# Altium schematic sheet right_led_gaze.SchDoc
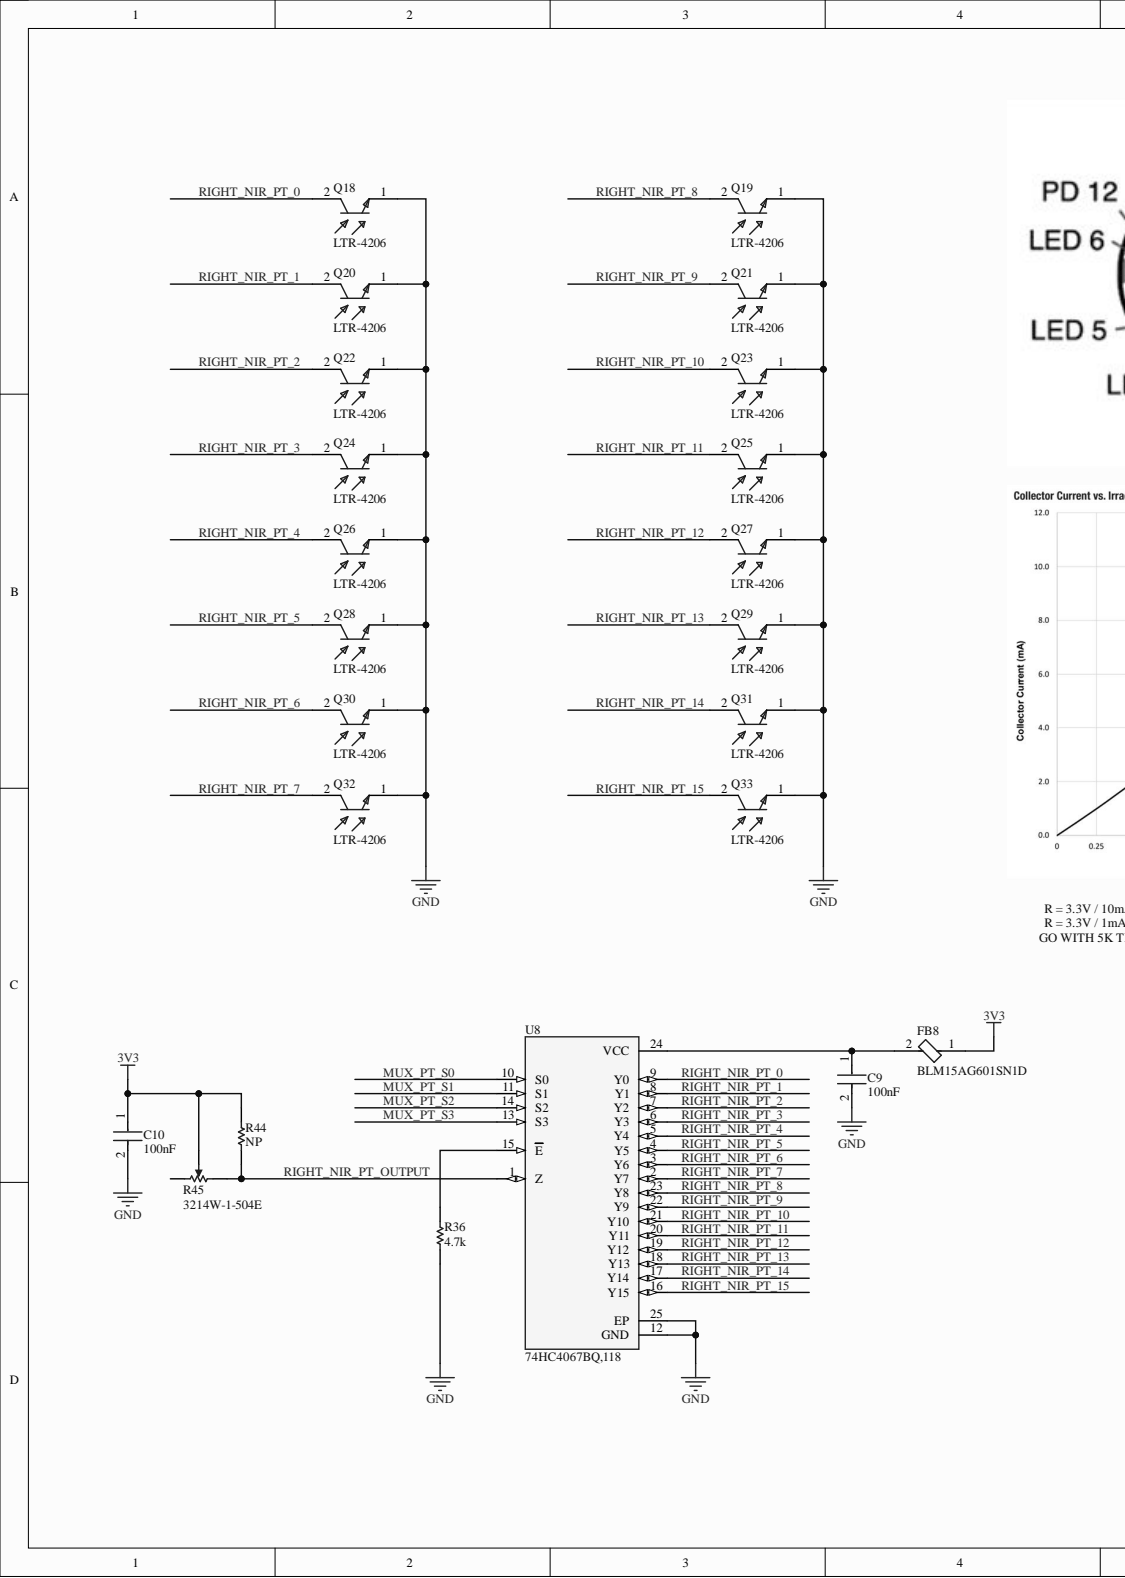
[diagram p0: right_led_gaze.SchDoc, left]
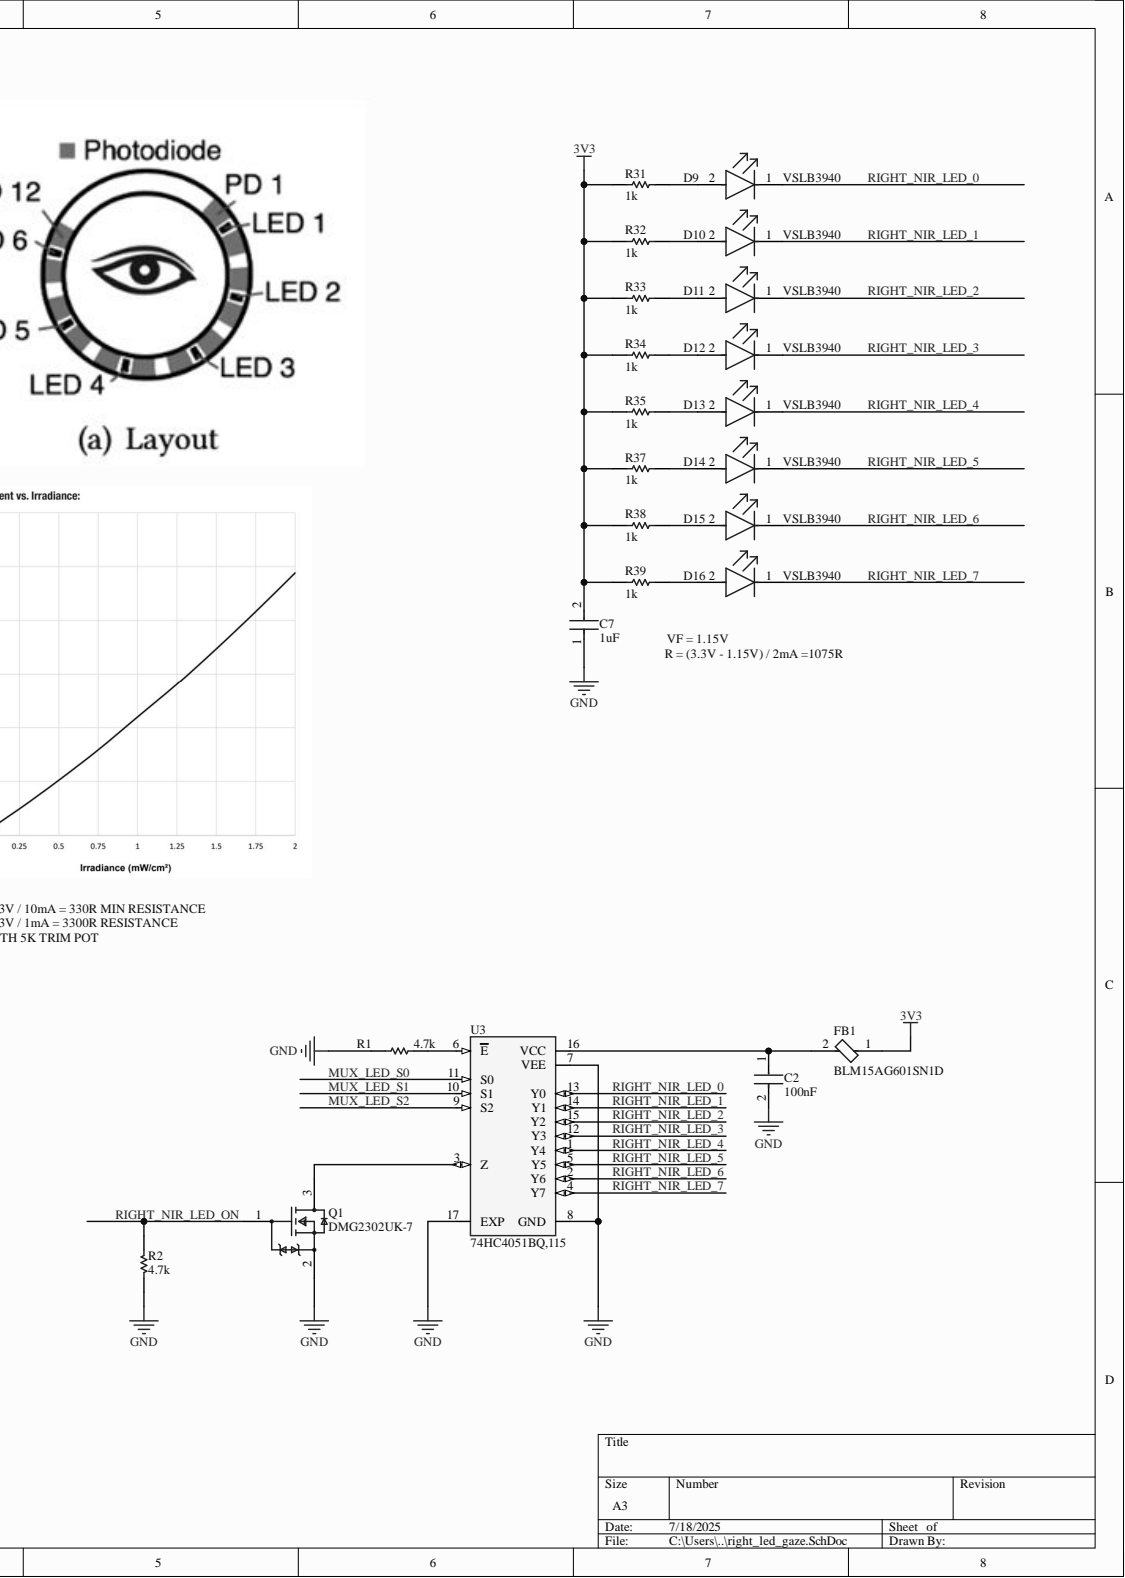
[diagram p1: right_led_gaze.SchDoc, right]

## Parts
C2  Capacitance: 100nF  [FP-GRM15-MFG]  (hidden comment: GRM155R71C104KA88D)
C7  value 1uF  [FP-CC0402-MFG]  (hidden comment: CC0402KRX5R5BB105)
C9  Capacitance: 100nF  [FP-GRM15-MFG]  (hidden comment: GRM155R71C104KA88D)
C10  Capacitance: 100nF  [FP-GRM15-MFG]  (hidden comment: GRM155R71C104KA88D)
D9  VSLB3940  [FP-VSLB3940-MFG]
D10  VSLB3940  [FP-VSLB3940-MFG]
D11  VSLB3940  [FP-VSLB3940-MFG]
D12  VSLB3940  [FP-VSLB3940-MFG]
D13  VSLB3940  [FP-VSLB3940-MFG]
D14  VSLB3940  [FP-VSLB3940-MFG]
D15  VSLB3940  [FP-VSLB3940-MFG]
D16  VSLB3940  [FP-VSLB3940-MFG]
FB1  BLM15AG601SN1D  [FP-BLM15-0_05-IPC_B]
FB8  BLM15AG601SN1D  [FP-BLM15-0_05-IPC_B]
Q1  DMG2302UK-7  [FP-SOT23-MFG]
Q18  LTR-4206  [FP-LTR-4206-MFG]
Q19  LTR-4206  [FP-LTR-4206-MFG]
Q20  LTR-4206  [FP-LTR-4206-MFG]
Q21  LTR-4206  [FP-LTR-4206-MFG]
Q22  LTR-4206  [FP-LTR-4206-MFG]
Q23  LTR-4206  [FP-LTR-4206-MFG]
Q24  LTR-4206  [FP-LTR-4206-MFG]
Q25  LTR-4206  [FP-LTR-4206-MFG]
Q26  LTR-4206  [FP-LTR-4206-MFG]
Q27  LTR-4206  [FP-LTR-4206-MFG]
Q28  LTR-4206  [FP-LTR-4206-MFG]
Q29  LTR-4206  [FP-LTR-4206-MFG]
Q30  LTR-4206  [FP-LTR-4206-MFG]
Q31  LTR-4206  [FP-LTR-4206-MFG]
Q32  LTR-4206  [FP-LTR-4206-MFG]
Q33  LTR-4206  [FP-LTR-4206-MFG]
R1  value 4.7k  [RESC1005X40X25LL05T10]  (hidden comment: CRCW04024K70FKEDHP)
R2  value 4.7k  [RESC1005X40X25LL05T10]  (hidden comment: CRCW04024K70FKEDHP)
R31  Resistance: 1k  [RESC1005X40X25NL05T05]  (hidden comment: ERJ-2RKF1001X)
R32  Resistance: 1k  [RESC1005X40X25NL05T05]  (hidden comment: ERJ-2RKF1001X)
R33  Resistance: 1k  [RESC1005X40X25NL05T05]  (hidden comment: ERJ-2RKF1001X)
R34  Resistance: 1k  [RESC1005X40X25NL05T05]  (hidden comment: ERJ-2RKF1001X)
R35  Resistance: 1k  [RESC1005X40X25NL05T05]  (hidden comment: ERJ-2RKF1001X)
R36  value 4.7k  [RESC1005X40X25LL05T10]  (hidden comment: CRCW04024K70FKEDHP)
R37  Resistance: 1k  [RESC1005X40X25NL05T05]  (hidden comment: ERJ-2RKF1001X)
R38  Resistance: 1k  [RESC1005X40X25NL05T05]  (hidden comment: ERJ-2RKF1001X)
R39  Resistance: 1k  [RESC1005X40X25NL05T05]  (hidden comment: ERJ-2RKF1001X)
R44  value NP  [RESC1005X40X25LL05T10]  (hidden comment: CRCW04024K70FKEDHP)
R45  3214W-1-504E  [BOUR-3214W_V]
U3  74HC4051BQ,115  [QFN50P250X350X100-17N]
U8  74HC4067BQ,118  [QFN50P550X350X100-25N410X210]

## Nets
3V3: C7.2 C10.1 FB1.1 FB8.1 R31.1 R32.1 R33.1 R34.1 R35.1 R37.1 R38.1 R39.1 R44.2 R45.2
GND: C2.2 C7.1 C9.2 C10.2 Q1.2 Q18.1 Q19.1 Q20.1 Q21.1 Q22.1 Q23.1 Q24.1 Q25.1 Q26.1 Q27.1 Q28.1 Q29.1 Q30.1 Q31.1 Q32.1 Q33.1 R1.2 R2.1 R36.1 U3.7(VEE) U3.8(GND) U3.17(EXP) U8.12(GND) U8.25(EP)
MUX_LED_S0: U3.11(S0)
MUX_LED_S1: U3.10(S1)
MUX_LED_S2: U3.9(S2)
MUX_PT_S0: U8.10(S0)
MUX_PT_S1: U8.11(S1)
MUX_PT_S2: U8.14(S2)
MUX_PT_S3: U8.13(S3)
RIGHT_NIR_LED_0: D9.1 U3.13(Y0)
RIGHT_NIR_LED_1: D10.1 U3.14(Y1)
RIGHT_NIR_LED_2: D11.1 U3.15(Y2)
RIGHT_NIR_LED_3: D12.1 U3.12(Y3)
RIGHT_NIR_LED_4: D13.1 U3.1(Y4)
RIGHT_NIR_LED_5: D14.1 U3.5(Y5)
RIGHT_NIR_LED_6: D15.1 U3.2(Y6)
RIGHT_NIR_LED_7: D16.1 U3.4(Y7)
RIGHT_NIR_LED_ON: Q1.1 R2.2
RIGHT_NIR_PT_0: Q18.2 U8.9(Y0)
RIGHT_NIR_PT_1: Q20.2 U8.8(Y1)
RIGHT_NIR_PT_2: Q22.2 U8.7(Y2)
RIGHT_NIR_PT_3: Q24.2 U8.6(Y3)
RIGHT_NIR_PT_4: Q26.2 U8.5(Y4)
RIGHT_NIR_PT_5: Q28.2 U8.4(Y5)
RIGHT_NIR_PT_6: Q30.2 U8.3(Y6)
RIGHT_NIR_PT_7: Q32.2 U8.2(Y7)
RIGHT_NIR_PT_8: Q19.2 U8.23(Y8)
RIGHT_NIR_PT_9: Q21.2 U8.22(Y9)
RIGHT_NIR_PT_10: Q23.2 U8.21(Y10)
RIGHT_NIR_PT_11: Q25.2 U8.20(Y11)
RIGHT_NIR_PT_12: Q27.2 U8.19(Y12)
RIGHT_NIR_PT_13: Q29.2 U8.18(Y13)
RIGHT_NIR_PT_14: Q31.2 U8.17(Y14)
RIGHT_NIR_PT_15: Q33.2 U8.16(Y15)
RIGHT_NIR_PT_OUTPUT: R44.1 R45.1 U8.1(Z)
NetC2_1: C2.1 FB1.2 U3.16(VCC)
NetC9_1: C9.1 FB8.2 U8.24(VCC)
NetD9_2: D9.2 R31.2
NetD10_2: D10.2 R32.2
NetD11_2: D11.2 R33.2
NetD12_2: D12.2 R34.2
NetD13_2: D13.2 R35.2
NetD14_2: D14.2 R37.2
NetD15_2: D15.2 R38.2
NetD16_2: D16.2 R39.2
NetQ1_3: Q1.3 U3.3(Z)
NetR1_1: R1.1 U3.6(E)
NetR36_2: R36.2 U8.15(E)

Not connected: R45 3

## Library data of the parts
C2 C9 C10 = CMP-2100-03641-2: CAP CER 0.1UF 16V X7R 0402; Manufacturer: Murata; Material: Ceramic; Standoff Height: 0mm; Tolerance: 10%; Value: 0.10μF; Voltage Rating: 16Vdc; Category: Cap; Component Kind: Standard; ComponentLink2Description: Datasheet; ComponentLink2URL: https://search.murata.co.jp/Ceramy/image/img/A01X/G101/ENG/GRM155R71H471KA01-01A.pdf; Device Class L1: Passive Components; Device Class L2: Capacitors; Device Class L3: Ceramic Capacitors; Digikey Description: CAP CER 0.1UF 16V X7R 0402; Height: 0.55mm; Ipc Land Pattern Name: CAPC10050X219; Lead Free: yes; Library Name: Altium Content Vault; Manufacturer Part Number: GRM155R71C104KA88D; Mouser Description: 0402 0.1 uF 16V ±10 % Tolerance X7R SMT Multilayer Ceramic Capacitor; Octopart: https://octopart.com/grm155r71c104ka88d-murata-196880; Package: GRM15; RoHS: TRUE; Temperature: -55°C to +125°C; Temperature Characteristic: X7R; Temperature Range High: +125°C; Temperature Range Low: -55°C
C7 = CMP-2100-03591-2: CAP CER 1UF 6.3V X5R 0402; Manufacturer: YAGEO; Automotive Grade: NA; Material: Ceramic; Temperature Coefficient: 30ppm/°C; Tolerance: 0.1; Automotive: No; Capacitance: 1 uF; Category: Cap; Component Kind: Standard; ComponentLink2Description: Datasheet; ComponentLink2URL: http://www.yageo.com/documents/recent/UPY-GP_NP0_16V-to-50V_16.pdf; Device Class L1: Passive Components; Device Class L2: Capacitors; Device Class L3: Ceramic Capacitors; Digikey Description: CAP CER 1UF 6.3V X5R 0402; Footprint Url: http://www.yageo.com/exep/pages/download/literatures/UPY-C_GEN_24.pdf; Height: 0.55mm; Ipc Land Pattern Name: CAPC10050X50; Lead Free: Yes; Library Name: Altium Content Vault; Manufacturer Part Number: CC0402KRX5R5BB105; Mouser Description: Multilayer Ceramic Capacitors MLCC - SMD/SMT 1uF 6.3V X5R 10%; Octopart: https://octopart.com/cc0402krx5r5bb105-yageo-42244618; Package: CC0402; RoHS: TRUE; Temperature: -55°C to +125°C; Temperature Characteristic: X5R; Temperature Range High: +125°C; Temperature Range Low: -55°C; Voltage Rating: 6.3 V
D9-D16 = CMP-00030-18785862-1: VSLB3940 940 nm 100 mA ±22° Through Hole High Speed Infrared Emitting Diode - T-1; Fall Time: 15ns; Forward Current: 100mA; Forward Voltage: 1.35V; Lens Style: Circular, Diffused, Round; Max Power Dissipation: 160mW; Number of Elements: 1; Peak Wavelength: 940nm; Reverse Voltage: 5V; Viewing Angle: 44°; Case/Package: T; Component Kind: Standard; Depth: 3.2mm; Lead Free: Lead Free; Length: 3.2mm; Library Name: Altium Content Vault; Max Operating Temperature: 85°C; Min Operating Temperature: -25°C; Mount: Through Hole; NexarPartId: 18785862; Number of LEDs: 1; Number of Pins: 2; Orientation: Top View; Packaging: Bulk; Power Dissipation: 160mW; Power Rating: 160mW; Radiation Hardening: No; REACH SVHC: Unknown; Rise Time: 15ns; RoHS: Compliant; Test Current: 100mA; Wavelength: 940nm
FB1 FB8 = CMP-0686-00187-4: Chip Ferrite Bead, 0402, 600Ω @ 100MHz, 0.52Ω, 25%, 300mA; DC Resistance (DCR): 520mR; DC Resistance-Max: 600mR; Impedance: 600R; Material: Steel; Number of Circuits: 1; Shielding: Unshielded; Case Code (Imperial): 0402; Case Code (Metric): 1005; Case/Package: 0402; Component Kind: Standard; Core Material: Ferrite; Current Rating: 300mA; DC Current: 300mA; Depth: 0.55mm; Frequency: 100MHz; Height: 0.5mm; Lead Free: Lead Free; Length: 1mm; Library Name: Altium Content Vault; Max Current Rating: 300mA; Max DC Current: 300mA; Max Operating Temperature: 125°C; Military Standard: Not; Min Operating Temperature: -55°C; Number of Lines: 1; Number of Pins: 2; Package Quantity: 10000; Packaging: Tape and Reel; Radiation Hardening: No; REACH SVHC: No SVHC; RoHS Compliant: Yes; Series: BLM15AG_SN; Termination: SMD/SMT; Test Frequency: 100MHz; Tolerance: 25%; Width: 0.5mm
Q1 = CMP-12801-000001-1: MOSFET N-CH 20V 2.8A SOT23; Manufacturer: Diodes Inc.; Automotive Grade: Grade 0; Depletion Mode: False; Drain To Source Resistance: 61mΩ; Gate Charge At Vgs: 2.8nC @ 10V; Gate To Source Voltage: 12V; Input Capacitace At Vds: 130pF @ 10V; Max Forward Diode Voltage: 1.2V; Max Junction Temp: +150°C; Number Of Channels: 1; Power Dissipation: 0.66W; Pulse Drain Current: 12A; Reverse Recovery Charge: 0.5nC; Reverse Recovery Time: 5.3ns; Rthja Max: 192°C/W; Standoff Height: 0.013mm; Threshold Vgs Max: 1V; Threshold Vgs Min: 0.3V; Turn Off Delay Time: 4.2ns; Turn On Delay Time: 0.6ns; Typ Forward Diode Voltage: 0.7V; Automotive: Yes; Category: Trans; Component Kind: Standard; ComponentLink2Description: Datasheet; ComponentLink2URL: https://www.diodes.com/assets/Datasheets/DMG2302UK.pdf; Continuous Drain Current: 2.8A; Device Class L1: Discrete Semiconductors; Device Class L2: Transistors; Device Class L3: MOSFETs; Digikey Description: MOSFET N-CH 20V 2.8A SOT23; Drain To Source Breakdown Voltage: 20V; Drain To Source Voltage: 20V; Footprint Url: https://www.diodes.com/assets/Package-Files/SOT23.pdf; Height: 1.1mm; Lead Free: Yes; Library Name: Altium Content Vault; Manufacturer Part Number: DMG2302UK-7; Number Of N Channels: 1; Octopart: https://octopart.com/dmg2302uk-7-diodes+inc.-73850182; Package: SOT23; RoHS: TRUE; Temperature: -55°C to +150°C; Temperature Range High: +150°C; Temperature Range Low: -55°C
Q18-Q33 = CMP-22030-000001-1: SENSOR PHOTO 940NM TOP VIEW T1; Manufacturer: Lite-On; Collector Emitter Breakdown Voltage: 30V; Dark Current: 0.1uA; Fall Time: 10us; Max Collector Current: 9.6mA; Power Dissipation: 100mW; Viewing Angle: 20°; Wavelength: 900nm; Automotive: No; Category: Trans; Component Kind: Standard; ComponentLink2Description: Datasheet; ComponentLink2URL: http://optoelectronics.liteon.com/upload/download/DS-50-92-0072/R4206.pdf; Device Class L1: Sensors; Device Class L2: Optical Sensors; Device Class L3: Phototransistor; Digikey Description: SENSOR PHOTO 940NM TOP VIEW T1; Height: 6.65mm; Lead Free: Yes; Led Orientation: Top View; Library Name: Altium Content Vault; Manufacturer Part Number: LTR-4206; Mouser Description: Phototransistors Phototrans Clear; Octopart: https://octopart.com/ltr-4206-lite-on-707502; Package: LTR-4206; Rise Time: 10us; RoHS: TRUE; Temperature: -40°C to +85°C; Temperature Range High: +85°C; Temperature Range Low: -40°C
R1 R2 R36 R44 = CMP-2002-03050-1: Manufacturer: Vishay Dale; Component Kind: Standard; Library Name: Altium Content Vault; Part Number: CRCW04024K70FKEDHP
R31-R35 R37-R39 = CMP-1011-00510-4: Chip Resistor, 1 KOhm, +/- 1%, 100 mW, -55 to 155 degC, 0402 (1005 Metric), RoHS, Tape and Reel; Manufacturer: Basic Passives; Case\/Package: 0402; Power Rating: 100 mW; Voltage Rating: 50 V; Case Code (Imperial): 0402; Case Code (Metric): 1005; Component Kind: Standard; ComponentLink1Description: Manufacturer URL; ComponentLink1URL: http://www.panasonic.com/; ComponentLink2Description: Datasheet; ComponentLink2URL: http://industrial.panasonic.com/cdbs/www-data/pdf/RDA0000/AOA0000C86.pdf; DatasheetVersion: 04/2015; Height: 0.35 mm; Length: 1 mm; Library Name: Altium Content Vault; Max Operating Temperature: 155 degC; Min Operating Temperature: -55 degC; Mount: Surface Mount; Mounting Technology: Surface Mount; Package Quantity: 10000; PackageDescription: 2-Pin Surface Mount Device, Body 1 x 0.5 mm; PackageReference: 0402; Packaging: Tape and Reel; PartNumber: ERJ-2RKF1001X; Pins: 2; Radiation Hardening: No; REACH SVHC: Yes; RoHSCompliant: true; Tolerance: 1%; Width: 0.5 mm
R45 = CMP-2000-05579-1: 3214 - 5-Turn Trimpot(R) Trimming Potentiometer, 5 KOhm, +/- 10%, 0.25 W, -65 to 150 degC, RoHS, Tape and Reel; Manufacturer: Bourns; No. of Turns: 5; Potentiometer Mounting: SMD; Power Rating: 250mW; SVHC: NoSVHC(16-Jun-2014); Temperature Coefficient: ±100ppm/°C; Track Resistance: 5kohm; Component Kind: Standard; Datasheet URL: http://www.bourns.com/docs/Product-Datasheets/3214.pdf; Datasheet Version: 05/2016; Library Name: Altium Content Vault; Manufacturer URL: http://www.bourns.com/; Mounting Technology: SurfaceMount; Package Description: 3-Pin Surface Mount Device, Body 3.5 x 4.8 mm, Pitch 1.25 mm; Package Reference: 3214W; Resistance Tolerance: ±10%; ROHS COMPLIANT: Yes
U3 = 74HC4051BQ,115: 1 Circuit IC Switch 8:1 120Ohm 16-DHVQFN (2.5x3.5); MF: Nexperia USA Inc.; Check_prices: https://www.snapeda.com/parts/74HC4051BQ,115/Nexperia/view-part/?ref=eda; Component Kind: Standard; DigiKey_Part_Number: 1727-6049-2-ND; Library Name: 74HC4051BQ_115.IntLib; MP: 74HC4051BQ,115; Package: DHVQFN16-16 Nexperia USA Inc.; Purchase-URL: https://pricing.snapeda.com/search?q=74HC4051BQ,115&ref=eda; SnapEDA_Link: https://www.snapeda.com/parts/74HC4051BQ,115/Nexperia/view-part/?ref=snap
U8 = 74HC4067BQ,118: 1 Circuit IC Switch 16:1 120Ohm 24-DHVQFN (5.5x3.5); MF: Nexperia USA Inc.; Check_prices: https://www.snapeda.com/parts/74HC4067BQ,118/Nexperia/view-part/?ref=eda; Component Kind: Standard; Library Name: 74HC4067BQ_118.IntLib; MP: 74HC4067BQ,118; Package: DHVQFN24-24 Nexperia; SnapEDA_Link: https://www.snapeda.com/parts/74HC4067BQ,118/Nexperia/view-part/?ref=snap
